# Altium schematic sheet pay-optical-toplevel.SchDoc
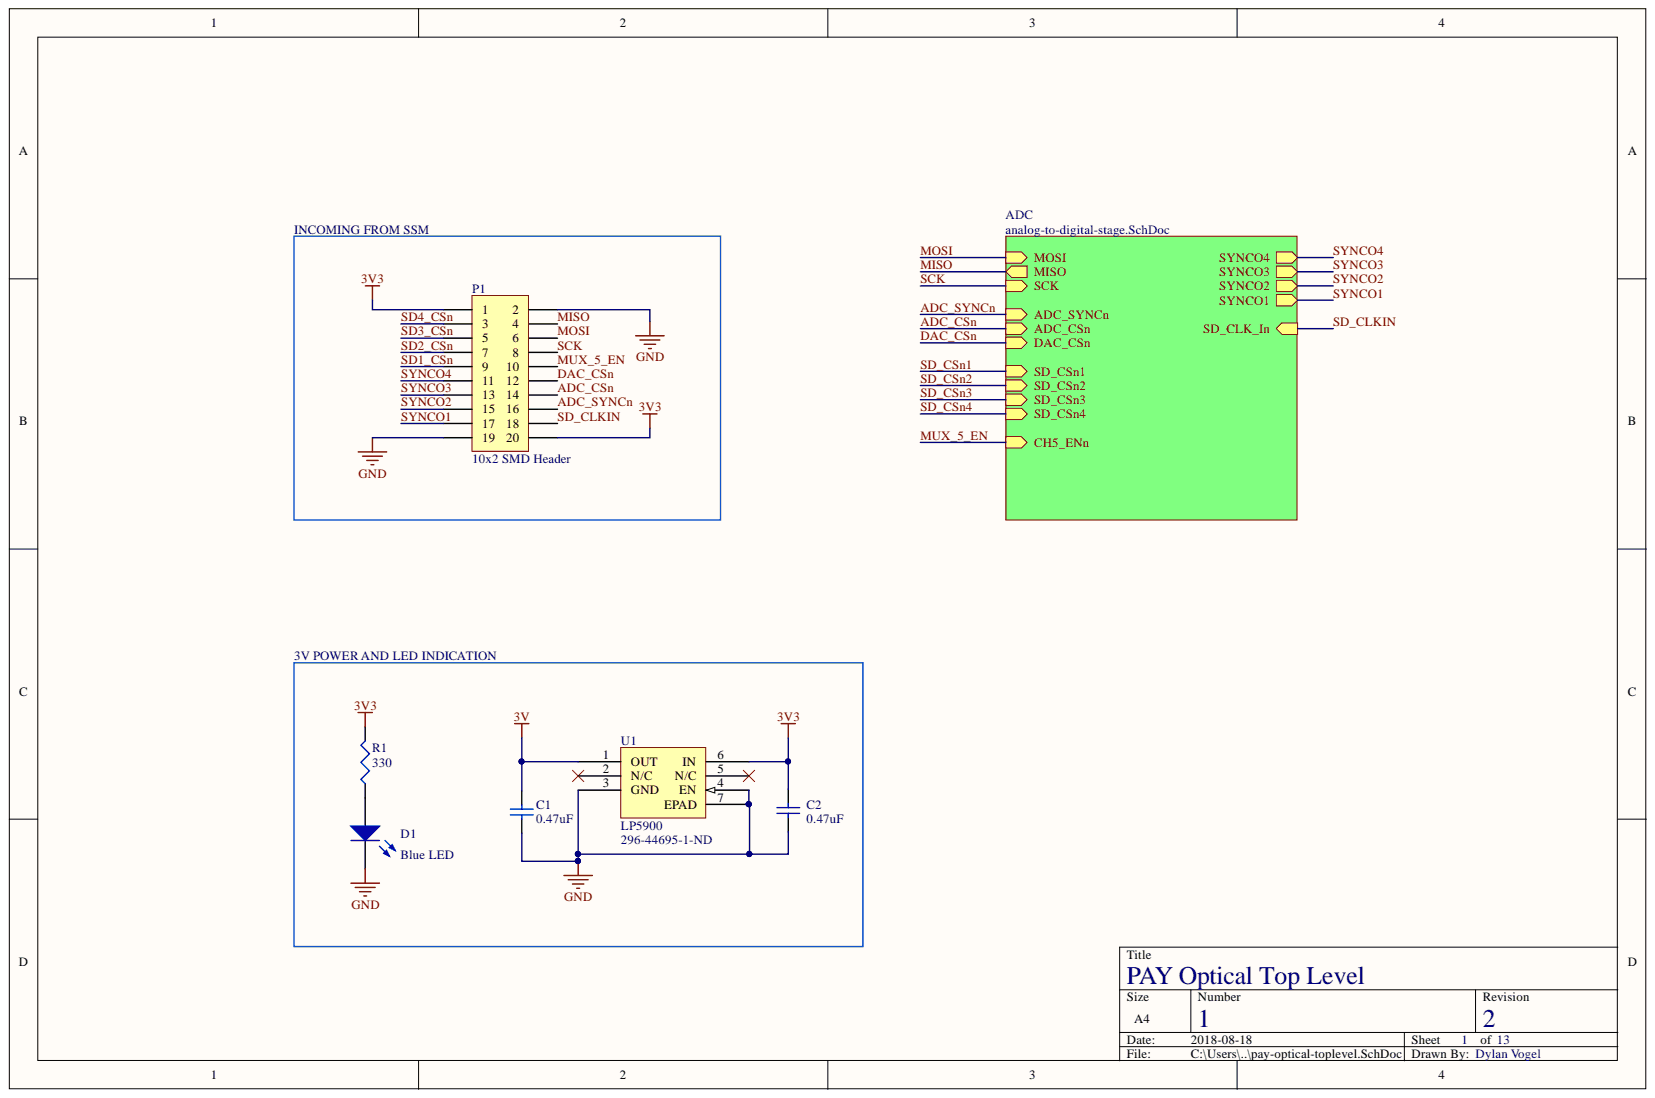
[diagram p0: pay-optical-toplevel.SchDoc]

## Parts
C1  0.47uF  [1608[0603]]
C2  0.47uF  [1608[0603]]
D1  Blue LED  [0603-LED]
P1  10x2 SMD Header  [CON20_2X10_DUA00]
R1  330  [0402]
U1  LP5900  Supplier Part Number 1: 296-44695-1-ND  [DFN220X250X80-7N]

## Nets
3V: C1.2 U1.1(OUT)
3V3: C2.2 P1.1 P1.20 R1.2 U1.6(IN)
GND: C1.1 C2.1 D1.2 P1.2 P1.19 U1.3(GND) U1.4(EN) U1.7(EPAD)
ADC_CSn: P1.14
ADC_SYNCn: P1.16
DAC_CSn: P1.12
MISO: P1.4
MOSI: P1.6
MUX_5_EN: P1.10
SCK: P1.8
SD1_CSn: P1.9
SD2_CSn: P1.7
SD3_CSn: P1.5
SD4_CSn: P1.3
SD_CLKIN: P1.18
SYNCO1: P1.17
SYNCO2: P1.15
SYNCO3: P1.13
SYNCO4: P1.11
NetD1_1: D1.1 R1.1
NetU1_2: U1.2(N/C)
NetU1_5: U1.5(N/C)
SD_CSn1:
SD_CSn2:
SD_CSn3:
SD_CSn4:

## Library data of the parts
C1 C2 = Cap Semi: Capacitor (Semiconductor SIM Model); Component Kind: Standard; LatestRevisionDate: 29-May-2009; LatestRevisionNote: IPC-7351 Footprint Added.; Library Name: Miscellaneous Devices.IntLib; PackageDescription: Chip Capacitor; PackageReference: C1206; Published: 8-Jun-2000; Signal Integrity: Cap Semi; Simulation: CAP; Supplier: Digi-Key; Supplier 1: Digi-Key; Value: 0.47uF; Publisher: Altium Limited
D1 = LED2: Typical RED, GREEN, YELLOW, AMBER GaAs LED; Component Kind: Standard; LatestRevisionDate: 17-Jul-2002; LatestRevisionNote: Re-released for DXP Platform.; Library Name: Miscellaneous Devices.IntLib; PackageDescription: SM LED; 2 Flat Leads; PackageReference: 3.2X1.6X1.1; PackageVersion: Feb-2002; Published: 8-Jun-2000; Simulation: LED2; Supplier 1: Digi-Key; Publisher: Altium Limited
P1 = Header 10X2: 10x2 SMD 50mil Incoming from SSM; Component Kind: Standard; LatestRevisionDate: 17-Jul-2002; LatestRevisionNote: Re-released for DXP Platform.; Library Name: Miscellaneous Connectors.IntLib; Signal Integrity: Connector; Supplier 1: Digi-Key; Supplier Part Number 1: SAM11274-ND; Publisher: Altium Limited
R1 = Res3: Power indication LED; Component Kind: Standard; LatestRevisionDate: 29-May-2009; LatestRevisionNote: IPC-7351 Footprint Added.; Library Name: Miscellaneous Devices.IntLib; PackageDescription: Chip Resistor; PackageReference: J1-0603; Published: 8-Jun-2000; Signal Integrity: Res; Simulation: RESISTOR; Supplier 1: Digi-Key; Value: 330; Publisher: Altium Limited
U1 = LP5900: Low noise 3V low dropout regulator for analog and RF applications.; Component Kind: Standard; Supplier 1: Digi-Key; Library Name: PAY.SchLib
